# Altium schematic sheet USB.SchDoc(USB)
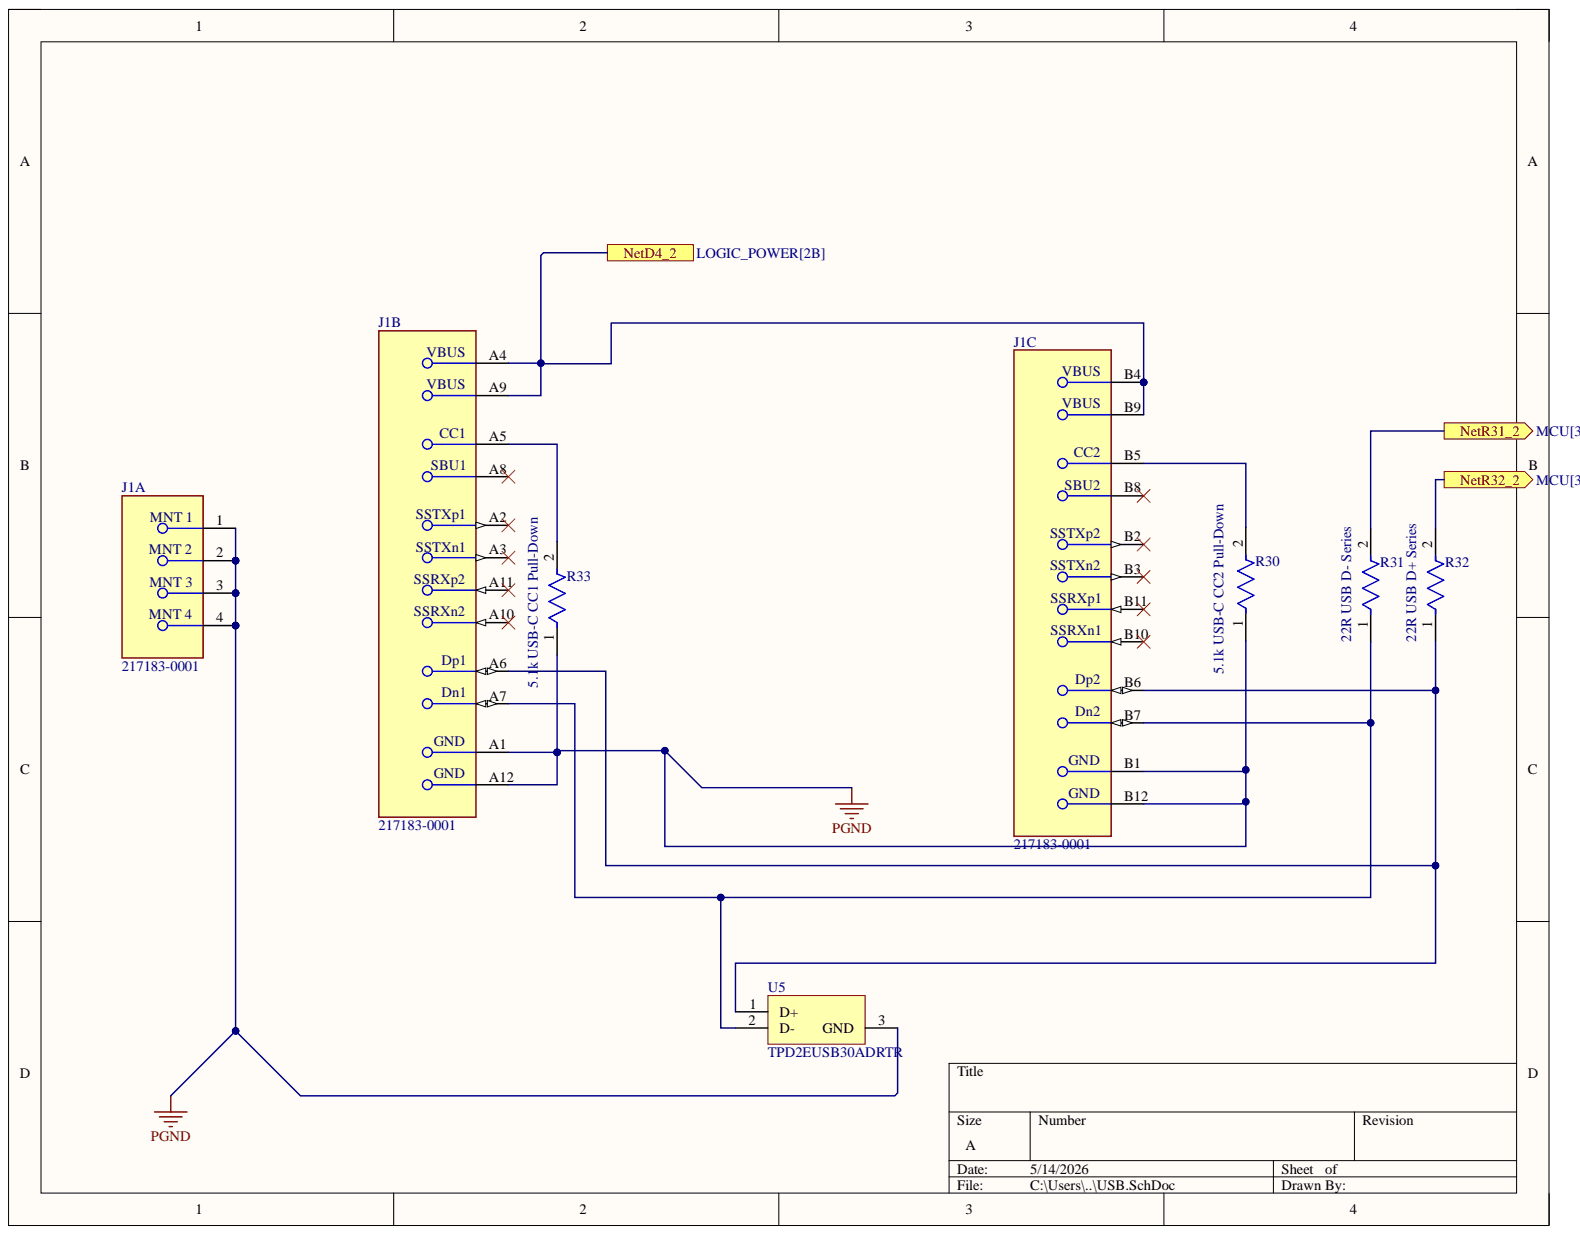
[diagram p0: USB.SchDoc]

## Parts
J1  217183-0001  [FP-217183-0001-MFG]  (parts A,B,C)
R30  5.1k USB-C CC2 Pull-Down  [FP-RN73R1E-IPC_C]
R31  22R USB D- Series  Resistance: 22R  [FP-RMCF0603-IPC_A]
R32  22R USB D+ Series  Resistance: 22R  [FP-RMCF0603-IPC_A]
R33  5.1k USB-C CC1 Pull-Down  [FP-RN73R1E-IPC_C]
U5  TPD2EUSB30ADRTR  [DRT0003A]

## Ports
USB_5V, USB_D+, USB_D-

## Nets
GND: J1.1 J1.2 J1.3 J1.4 J1.A1 J1.A12 J1.B1 J1.B12 R30.1 R33.1 U5.3
USB_5V: J1.A4 J1.A9 ; port USB_5V
NetJ1_A5: J1.A5 R33.2
NetJ1_A6: J1.A6 J1.B6 R32.1 U5.1
NetJ1_A7: J1.A7 J1.B7 R31.1 U5.2
NetJ1_B4: J1.B4 J1.B9
NetJ1_B5: J1.B5 R30.2
NetR31_2: R31.2
NetR32_2: R32.2

Not connected: J1 A2 A3 A8 A10 A11 B2 B3 B8 B10 B11

## Library data of the parts
J1 = CMP-04767-000015-1: TOP-MOUNT HYBRID 24POS (TYPE C R; Component Kind: Standard; Library Name: Altium Content Vault
R30 R33 = CMP-04015-008529-1: 5.1kΩ ±1% 0.063W, 1/16W Chip Resistor 0402 (1005 Metric) Moisture Resistant Thin Film; Component Kind: Standard; Library Name: Altium Content Vault
R31 R32 = CMP-26527-002321-1: 22Ω ±5% 0.1W 0603 Thick Film Chip Resistor AEC-Q200 compliant; Max Power Dissipation: 100mW; Temperature Coefficient: 200ppm/°C; Case/Package: 0603; Component Kind: Standard; Contact Plating: Tin; Features: Automotive AEC-Q200; Height: 0.022inch; Length: 0.061inch; Library Name: Altium Content Vault; Max Operating Temperature: 155°C; Min Operating Temperature: -55°C; Mount: Surface Mount; Number of Terminations: 2; Packaging: Tape and Reel; Radiation Hardening: No; Resistor Type: Thick Film; RoHS Compliant: Yes; Series: RMCF; Tolerance: 5%; Width: 0.031inch
U5 = CMP-0326-00047-3: ESD / Surge Solution for High Speed, Low Voltage Interface, 2 Channels, -40 to +85 degC, 3-pin SOT (DRT), Green (RoHS & no Sb/Br); Manufacturer: Texas Instruments; 1394 / Firewire: TRUE; Breakdown Voltage(Min)(V): 4.5; Differential Capacitance(pF): 0.05; IEC 61000-4-2 Air-Gap(+/- kV): 8; IO Capacitance(Typ)(pF): 0.7; IO Leakage Current(nA): 10; SubFamily: ESD Solution; Component Kind: Standard; ComponentLink1Description: Datasheet; ComponentLink1URL: http://www.ti.com/general/docs/lit/getliterature.tsp?genericPartNumber=TPD2EUSB30A&fileType=pdf; ComponentLink2Description: URL Link1; ComponentLink2URL: SamplesURL:http://www.ti.com/product/tpd2eusb30a#samples; DatasheetVersion: SLVSAC2C; Eco-Plan: Green (RoHS & no Sb/Br); HDMI: TRUE; IEC 61000-4-2 Contact(+/- kV): 8; Library Name: Altium Content Vault; Mounting Technology: Surface Mount; PackageDescription: 3-Flat Lead Plastic Small Outline, 1 x 1 mm (inc leads), 0.35 mm Pitch; PackageReference: DRT0003A; PackageVersion: revD, Jun-2008; PartNumber: TPD2EUSB30ADRTR; Serial ATA / eSATA: TRUE; USB Full Speed: TRUE; USB High Speed: TRUE; USB Super Speed: TRUE
